# Altium schematic sheet [4]-MCU_MEMORY.SchDoc([4]-MCU_MEMORY)
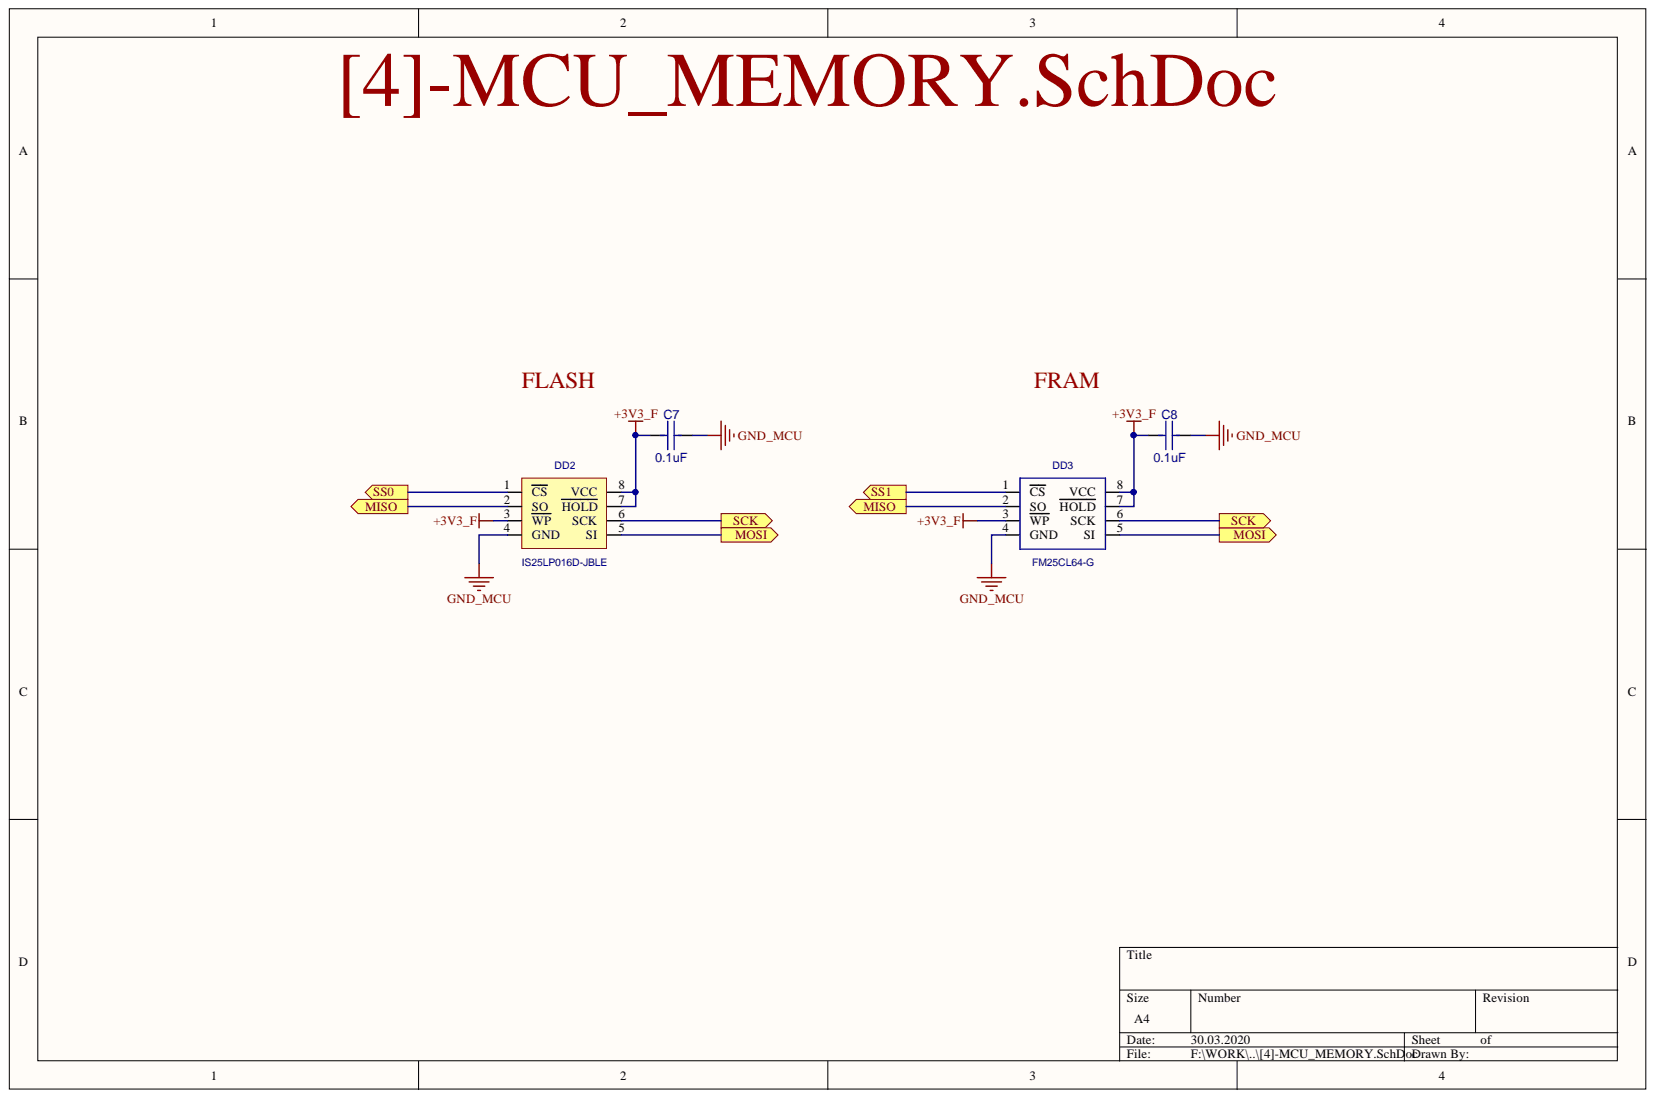
[diagram p0: [4]-MCU_MEMORY.SchDoc]

## Parts
C7  0.1uF  [CC0603]
C8  0.1uF  [CC0603]
DD2  IS25LP016D-JBLE  [EIAJ_SO8]
DD3  FM25CL64-G  [SO-8]

## Ports
MISO, MOSI, SCK, SS0, SS1

## Nets
+3V3_F: C7.1 C8.1 DD2.3(WP) DD2.7(HOLD) DD2.8(VCC) DD3.3(WP) DD3.7(HOLD) DD3.8(VCC)
GND_MCU: C7.2 C8.2 DD2.4(GND) DD3.4(GND)
MISO: DD2.2(SO) DD3.2(SO) ; port MISO
MOSI: DD2.5(SI) DD3.5(SI) ; port MOSI
SCK: DD2.6(SCK) DD3.6(SCK) ; port SCK
SS0: DD2.1(CS) ; port SS0
SS1: DD3.1(CS) ; port SS1

## Library data of the parts
C7 C8 = CC0603: Value: 0.1uF; Description: CC0603; Component Kind: Standard; Library Name: fizik_lib.SchLib
DD2 = S25FL016A0LMFI01: Value: IS25LP016D-JBLE; Description: S25FL016A0LMFI01; Component Kind: Standard; Library Name: fizik_lib.SchLib
DD3 = FM25CL64-G: Description: FM25CL64-G; Component Kind: Standard; Library Name: fizik_lib.SchLib
